# Altium schematic sheet PowerSupply.SchDoc
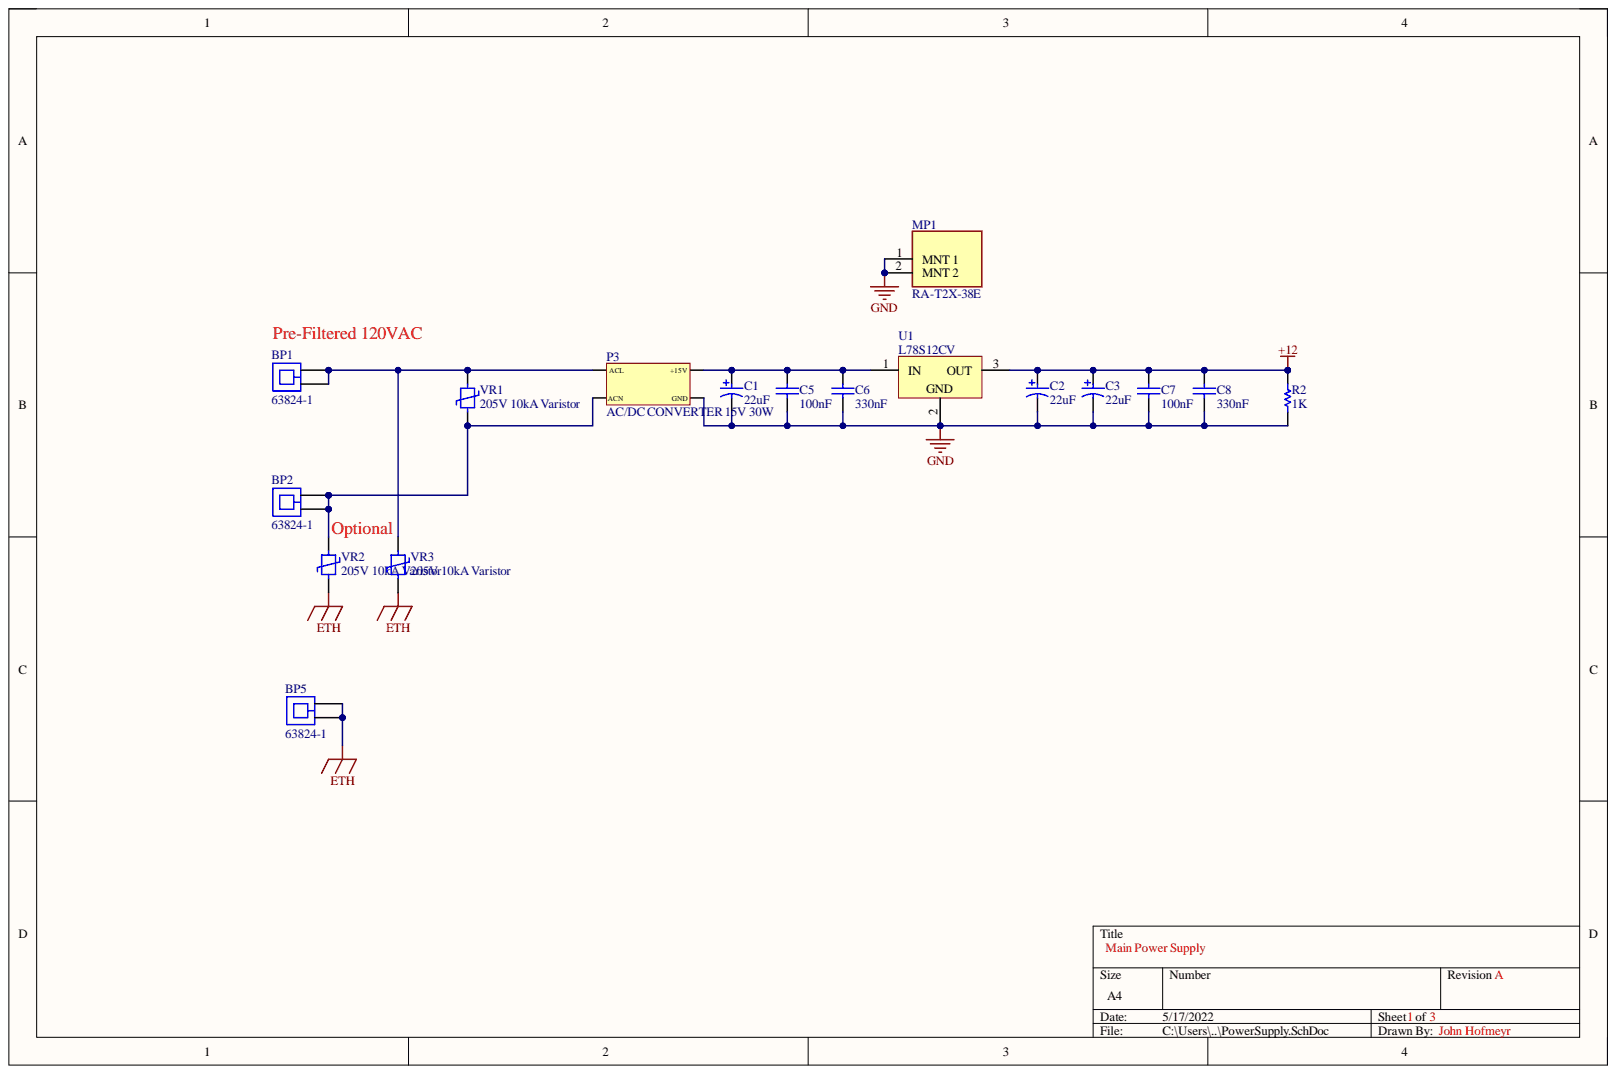
[diagram p0: PowerSupply.SchDoc]

## Parts
BP1  63824-1  [TECO-63824-1_V]
BP2  63824-1  [TECO-63824-1_V]
BP5  63824-1  [TECO-63824-1_V]
C1  22uF  [CAPPR55-200-1100X500X1200]
C2  22uF  [CAPPR55-200-1100X500X1200]
C3  22uF  [CAPPR55-200-1100X500X1200]
C5  100nF  [CAPC2013X140X50ML20T25]
C6  330nF  [CAPC2013X140X50ML20T25]
C7  100nF  [CAPC2013X140X50ML20T25]
C8  330nF  [CAPC2013X140X50ML20T25]
MP1  RA-T2X-38E  [FP-RA-T2X-38E-MFG]
P3  AC/DC CONVERTER 15V 30W  [AC_DC_Converter]
R2  1K  [RESA60-630X240]
U1  L78S12CV  [TO220]
VR1  205V 10kA Varistor  Varistor Voltage: 205V  [Axial 0.4]
VR2  205V 10kA Varistor  Varistor Voltage: 205V  [Axial 0.4]
VR3  205V 10kA Varistor  Max Surge Current: 10kA  Varistor Voltage: 205V  [Axial 0.4]

## Nets
+12: C2.1 C3.1 C7.2 C8.2 R2.2 U1.3
ETH: BP5.1 BP5.2 VR2.1 VR3.1
GND: C1.2 C2.2 C3.2 C5.1 C6.1 C7.1 C8.1 MP1.1 MP1.2 P3.3(GND) R2.1 U1.2
NetBP1_1: BP1.1 BP1.2 P3.1(ACL) VR1.2 VR3.2
NetBP2_1: BP2.1 BP2.2 P3.2(ACN) VR1.1 VR2.2
NetC1_1: C1.1 C5.2 C6.2 P3.4(+15V) U1.1

## Library data of the parts
BP1 BP2 BP5 = CMP-1684-00002-4: Printed Circuit Board Terminal and Disconnect, 2-Pin THD, RoHS, Tape and Reel; Manufacturer: TE Connectivity; Colour: Silver; Component Kind: Standard; ComponentLink1Description: Manufacturer URL; ComponentLink1URL: http://www.te.com/; ComponentLink2Description: Datasheet; ComponentLink2URL: http://www.te.com/commerce/DocumentDelivery/DDEController?Action=showdoc&DocId=Customer+Drawing%7F63824%7FR%7Fpdf%7FEnglish%7FENG_CD_63824_R_baseFilename.pdf%7F63824-1; Contact Material: Brass; Contact Plating: Tin; DatasheetVersion: Rev. R, 06/2013; Length: 13.63 mm; Library Name: Altium Content Vault; Mounting Technology: Through Hole; Package Quantity: 10000; PackageDescription: 2-Pin Through Hole Device, Body 7.92 x 3.08 mm, Pitch 5.08 mm; PackageReference: 63824-1; Packaging: Tape and Reel; REACH SVHC: Unknown; RoHSCompliant: True
C1-C3 = CMP-2100-03624-1: Aluminum Electrolytic Capacitor, 22 uF, +/- 20%, 50 V, -40 to 85 degC, 2-Pin THD, RoHS, Bulk; Manufacturer: Panasonic; Dissipation Factor: 0.12%; Lead Diameter: 0.5mm; Lead/Base Style: Straight; Leakage Current: 3uA; Life (Hours): 2000Hour; Ripple Current: 100mA; Voltage: 50V; Capacitance: 22uF; Case/Package: Radial; Component Kind: Standard; Datasheet URL: https://industrial.panasonic.com/cdbs/www-data/pdf/RDF0000/ABA0000C1218.pdf; Datasheet Version: Rev. 05, 10/2016; Diameter: 5mm; Height: 11mm; Height - Seated (Max): 0.472inch; Lead Pitch: 2mm; Length: 11mm; Library Name: Altium Content Vault; Manufacturer URL: http://www.panasonic.com/; Max Operating Temperature: 85degC; Min Operating Temperature: -40degC; Mount: ThroughHole; Package Description: 2-Pin Radial Lead, Dia 5 mm, Height 12 mm; Package Quantity: 200; Package Reference: CAPPR55-200-1100X500X1200; Packaging: Bulk; Radiation Hardening: No; REACH SVHC: NoSVHC; Ripple Current (AC): 100mA; RoHSCompliant: true; Termination: Radial; Tolerance: 20%; Voltage Rating: 50V; Voltage Rating (DC): 50V
C5 C7 = CMP-2007-04689-1: Manufacturer: AVX Interconnect / Elco; Part Number: 08055D104KAT2A; Component Kind: Standard; Library Name: Altium Content Vault
C6 C8 = CMP-2007-03278-1: Manufacturer: Kemet; Part Number: C0805C334K3RACTU; Component Kind: Standard; Library Name: Altium Content Vault
MP1 = CMP-33535-000001-1: HEATSINK TO-220,TO-218,TO-247; Manufacturer: Ohmite; Natural Thermal Resistance: 3.9°C/W; Automotive: No; Category: UNK; Component Kind: Standard; ComponentLink2Description: Datasheet; ComponentLink2URL: https://www.ohmite.com/assets/docs/sink_f_r.pdf?r=false; Device Class L1: Electromechanical; Device Class L2: Thermal Management; Device Class L3: Heat Sinks; Digikey Description: HEATSINK TO-220,TO-218,TO-247; Height: 38.66mm; Lead Free: Yes; Library Name: Altium Content Vault; Manufacturer Part Number: RA-T2X-38E; Mating Package: TO-220, TO-218, TO-247; Mount: Through Hole; Mouser Description: Heat Sinks HTSNK TO-220 218 247 BLK ANODIZED; Octopart: https://octopart.com/ra-t2x-38e-ohmite-18893990; Package: RA-T2X-38E; RoHS: TRUE
P3 = IRM-30-15: 30W Encapsulated Board Mount Module AC/DC Power Supply with 15VDC Output; Manufacturer: Mean Well; Approvals: UL,CE,TUV,CB; Current - Output 1: 2A; Efficiency: 88%; Number of Outputs: 1; Case/Package: Module; Category: Power Supply Modules; Component Kind: Standard; ComponentLink1Description: http://www.farnell.com/datasheets/2547888.pdf?src-supplier=Farnell; ComponentLink1URL: http://www.farnell.com/datasheets/2547888.pdf?src-supplier=Farnell; ComponentLink2Description: http://www.mouser.com/ds/2/260/IRM-30-SPEC-806201.pdf?src-supplier=Mouser; ComponentLink2URL: http://www.mouser.com/ds/2/260/IRM-30-SPEC-806201.pdf?src-supplier=Mouser; ComponentLink3Description: http://www.jameco.com/Jameco/Products/ProdDS/2218628.pdf?src-supplier=Jameco; ComponentLink3URL: http://www.jameco.com/Jameco/Products/ProdDS/2218628.pdf?src-supplier=Jameco; ComponentLink4Description: https://www.meanwell.com/Upload/PDF/IRM-30/IRM-30-SPEC.PDF?src-supplier=Digi-Key; ComponentLink4URL: https://www.meanwell.com/Upload/PDF/IRM-30/IRM-30-SPEC.PDF?src-supplier=Digi-Key; ComponentLink5Description: http://www.farnell.com/datasheets/2547888.pdf?src-supplier=Newark; ComponentLink5URL: http://www.farnell.com/datasheets/2547888.pdf?src-supplier=Newark; Height: 24mm; Length: 69.5mm; Library Name: MainBoard.SchLib; Manufacturer Part Number: IRM-30-15; Max Operating Temperature: 70°C; Max Output Power: 30W; Min Operating Temperature: -30°C; Output Current: 2A; Output Power: 30W; Package Quantity: 144; Pricing 1: 1=14.95000, 10=14.52100, 25=13.66640, 50=13.23920, 100=12.81220, 250=12.38516, 500=11.95808 (USD); RoHS: Yes; Stock 1: 388; Supplier 1: Digi-Key; Supplier Part Number 1: 1866-3045-ND; Voltage - Output 1: 15V; Weight: 3.844862oz; Width: 39mm
R2 = CMP-1659-00075-1: Axial Resistor, 1 KOhm, +/- 1%, 250 mW, -55 to 155 degC, 2-Pin THD, RoHS, Bulk; Power Rating: 250 mW; Resistance: 10 kohm; Voltage Rating: 250 V; Case\Package: Axial; Component Kind: Standard; ComponentLink1Description: Manufacturer URL; ComponentLink1URL: http://www.yageo.com/; ComponentLink2Description: Datasheet; ComponentLink2URL: http://www.yageo.com/documents/recent/Yageo%20LR_MFR_2013.pdf; DatasheetVersion: Apr-13; Length: 0.248 inch; Library Name: Altium Content Vault; Max Operating Temperature: 155 degC; Min Operating Temperature: -55 degC; Package Quantity: 200; PackageDescription: 2-Pin Axial Lead, Dia 2.4 mm, Body Length 6.3 mm; PackageReference: MFR-25; Packaging: Bulk; RoHSCompliant: true; Tolerance: 1%
U1 = CMP-0244-00496-1: 2A Positive Voltage Regulator, 12V, 3-Pin TO-220; Component Kind: Standard; ComponentLink1Description: Manufacturer URL; ComponentLink1URL: http://www.st.com; ComponentLink2Description: Datasheet; ComponentLink2URL: http://www.st.com/internet/com/TECHNICAL_RESOURCES/TECHNICAL_LITERATURE/DATASHEET/CD00000449.pdf; ComponentLink3Description: Package Specification; ComponentLink3URL: http://www.st.com/internet/com/TECHNICAL_RESOURCES/TECHNICAL_LITERATURE/PACKAGE_INFORMATION/CD00004649.pdf; DatasheetVersion: 1/03/2010; Library Name: Altium Content Vault; PackageDescription: TO, Thru-Hole, Vertical, Heatsink Mounted; 3 In-Line Leads; Pitch 2.54 mm; PackageReference: TO220; PackageVersion: ver3.0
VR1-VR3 = B72220S2131K101: VARISTOR 205V 10KA DISC 20MM; Manufacturer: TDK EPCOS; Energy: 100J; Max Varistor Voltage: 225.5V; Max Voltage Rating (AC): 130V; Max Voltage Rating (DC): 170V; Min Varistor Voltage: 184.5V; Number of Circuits: 1; Case/Package: Metal; Category: Varistors; Component Kind: Standard; ComponentLink1Description: https://datasheet.ciiva.com/16944/siov-leaded-advanced-16944203.pdf?src-supplier=Avnet+Europe; ComponentLink1URL: https://datasheet.ciiva.com/16944/siov-leaded-advanced-16944203.pdf?src-supplier=Avnet+Europe; Library Name: MainBoard.SchLib; Manufacturer Part Number: B72220S2131K101; Mount: Through Hole; Package Quantity: 250; Packaging: Bulk; Pricing 1: 1=0.99000, 10=0.87800, 25=0.79280, 100=0.69380, 250=0.60888, 500=0.53808, 1000=0.42480, 2500=0.39648, 5000=0.37666 (USD); RoHS: Yes; Stock 1: 2917; Supplier 1: Digi-Key; Supplier Part Number 1: 495-1443-ND
  VR1 VR2: Max Surge Current: 10kA
